# Altium schematic sheet 9-Protections 1 of 2.SchDoc("9-Protections 1 of 2")
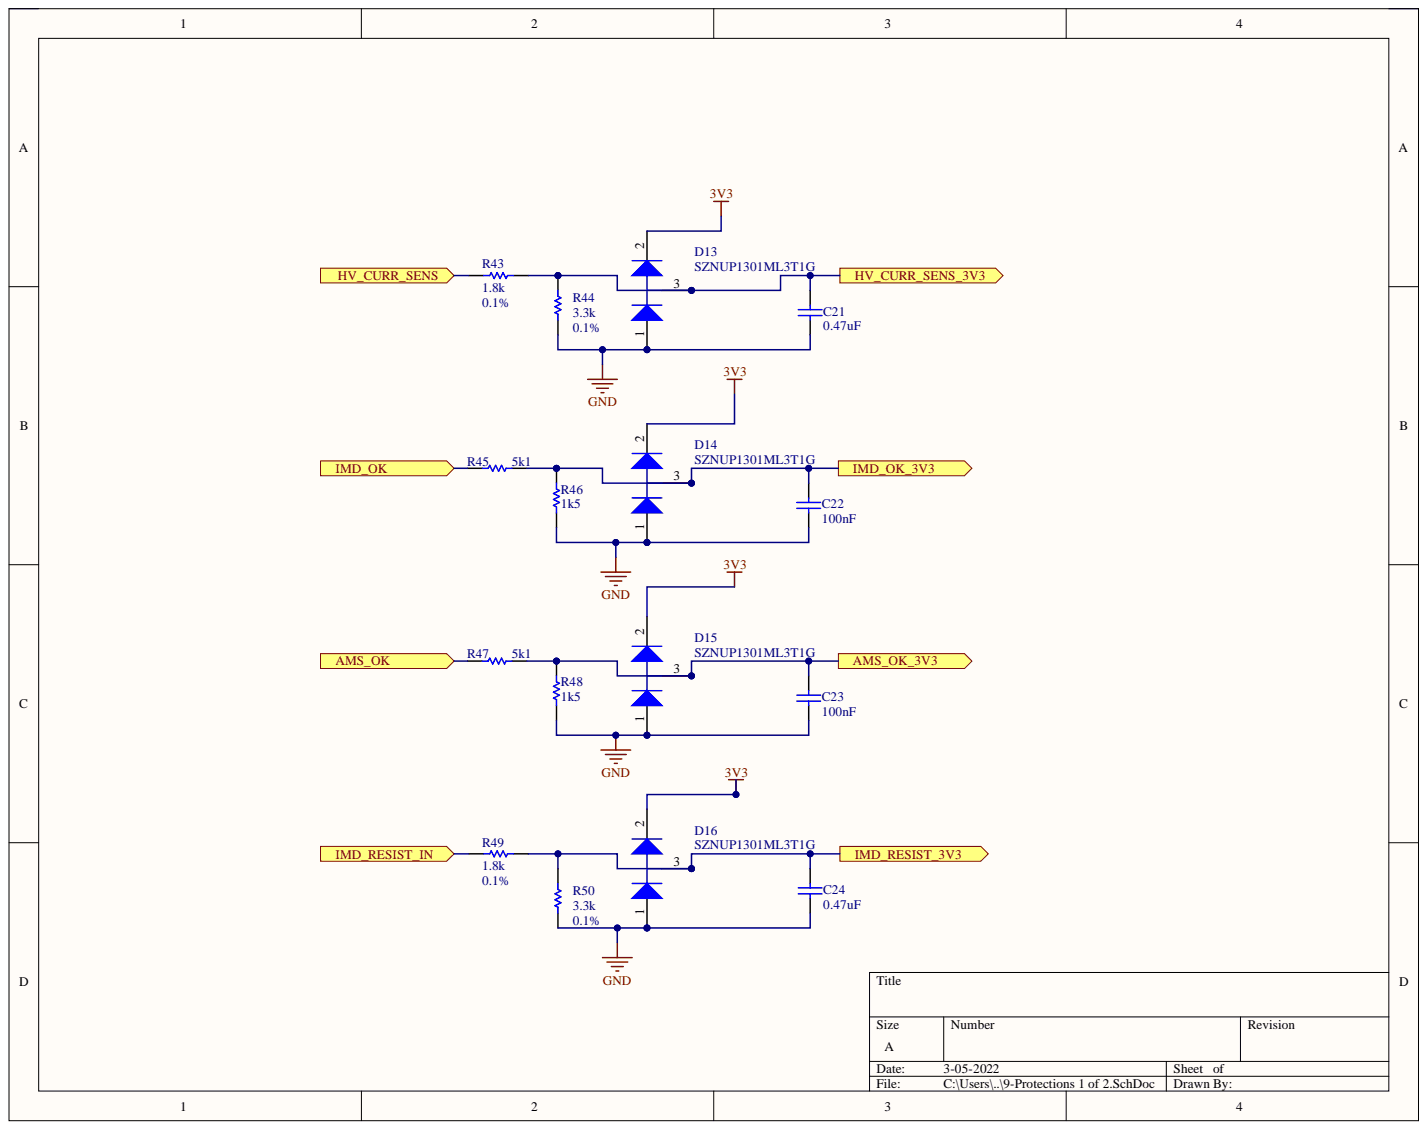
[diagram p0: 9-Protections 1 of 2.SchDoc]

## Parts
C21  value 0.47uF  [1608[0603]]  (hidden comment: Cap)
C22  value 100nF  [1608[0603]]  (hidden comment: Cap)
C23  value 100nF  [1608[0603]]  (hidden comment: Cap)
C24  value 0.47uF  [1608[0603]]  (hidden comment: Cap)
D13  SZNUP1301ML3T1G  [ONSC-SOT-23-3-318-08_V]
D14  SZNUP1301ML3T1G  [ONSC-SOT-23-3-318-08_V]
D15  SZNUP1301ML3T1G  [ONSC-SOT-23-3-318-08_V]
D16  SZNUP1301ML3T1G  [ONSC-SOT-23-3-318-08_V]
R43  value 1.8k  Resistance (Ohms): 1.8k  Tolerance: 0.1%  [1608[0603]]  (hidden comment: Res1)
R44  value 3.3k  Resistance (Ohms): 3.3k  Tolerance: 0.1%  [1608[0603]]  (hidden comment: Res1)
R45  value 5k1  [1608[0603]]  (hidden comment: Res1)
R46  value 1k5  [1608[0603]]  (hidden comment: Res1)
R47  value 5k1  [1608[0603]]  (hidden comment: Res1)
R48  value 1k5  [1608[0603]]  (hidden comment: Res1)
R49  value 1.8k  Resistance (Ohms): 1.8k  Tolerance: 0.1%  [1608[0603]]  (hidden comment: Res1)
R50  value 3.3k  Resistance (Ohms): 3.3k  Tolerance: 0.1%  [1608[0603]]  (hidden comment: Res1)

## Ports
AMS_OK, AMS_OK_3V3, HV_CURR_SENS, HV_CURR_SENS_3V3, IMD_OK, IMD_OK_3V3, IMD_RESIST_3V3, IMD_RESIST_IN

## Nets
3V3: D13.2 D14.2 D15.2 D16.2
GND: C21.1 C22.1 C23.1 C24.1 D13.1 D14.1 D15.1 D16.1 R44.1 R46.1 R48.1 R50.1
AMS_OK: R47.1 ; port AMS_OK
AMS_OK_3V3: C23.2 D15.3 R47.2 R48.2 ; port AMS_OK_3V3
HV_CURR_SENS: R43.2 ; port HV_CURR_SENS
HV_CURR_SENS_3V3: C21.2 D13.3 R43.1 R44.2 ; port HV_CURR_SENS_3V3
IMD_OK: R45.1 ; port IMD_OK
IMD_OK_3V3: C22.2 D14.3 R45.2 R46.2 ; port IMD_OK_3V3
IMD_RESIST_3V3: C24.2 D16.3 R49.1 R50.2 ; port IMD_RESIST_3V3
IMD_RESIST_IN: R49.2 ; port IMD_RESIST_IN

## Library data of the parts
C21 C24 = Cap: Capacitor; Manufacturer: Yageo; Manufacturer Part Number: CC0603KRX7R8BB474; Capacitance: 0.47µF; Temperature Coefficient: X7R; Tolerance: ±10%; Voltage - Rated: 25V; Applications: General Purpose; Category: Capacitors; Component Kind: Standard; ComponentLink1Description: http://www.yageo.com/documents/recent/UPY-GPHC_X7R_6.3V-to-50V_16.pdf; ComponentLink1URL: http://www.yageo.com/documents/recent/UPY-GPHC_X7R_6.3V-to-50V_16.pdf; Failure Rate: -; Features: -; Height - Seated (Max): -; Lead Spacing: -; Lead Style: -; Library Name: Miscellaneous Devices.IntLib; Mounting Type: Surface Mount, MLCC; Operating Temperature: -55°C ~ 125°C; Package / Case: 0603 (1608 Metric); Packaging: Cut Tape (CT); Part Status: Active; Pricing 1: 1=0.22, 10=0.158, 25=0.1156, 50=0.0898, 100=0.0745, 250=0.06168, 500=0.05226, 1000=0.04324 (CAD); Ratings: -; RoHS: RoHS Compliant; Signal Integrity: Cap; Simulation: CAP; Size / Dimension: 0.063" L x 0.031" W (1.60mm x 0.80mm); Stock 1: 11076; Supplier 1: Digi-Key; Supplier Part Number 1: 311-1805-1-ND; Thickness (Max): 0.035" (0.90mm)
C22 C23 = Cap: Capacitor; Manufacturer: Yageo; Manufacturer Part Number: CC0603KRX7R9BB104; Capacitance: 0.10µF; Temperature Coefficient: X7R; Tolerance: ±10%; Voltage - Rated: 50V; Applications: General Purpose; Category: Capacitors; Component Kind: Standard; ComponentLink1Description: http://www.yageo.com/documents/recent/UPY-GPHC_X7R_6.3V-to-50V_16.pdf; ComponentLink1URL: http://www.yageo.com/documents/recent/UPY-GPHC_X7R_6.3V-to-50V_16.pdf; Failure Rate: -; Features: -; Height - Seated (Max): -; Lead Spacing: -; Lead Style: -; Library Name: Miscellaneous Devices.IntLib; Mounting Type: Surface Mount, MLCC; Operating Temperature: -55°C ~ 125°C; Package / Case: 0603 (1608 Metric); Packaging: Cut Tape (CT); Part Status: Active; Pricing 1: 1=0.15, 10=0.043, 25=0.0312, 50=0.0232, 100=0.0189, 250=0.0158, 500=0.01346, 1000=0.01059 (CAD); Ratings: -; RoHS: RoHS Compliant; Signal Integrity: Cap; Simulation: CAP; Size / Dimension: 0.063" L x 0.031" W (1.60mm x 0.80mm); Stock 1: 4016262; Supplier 1: Digi-Key; Supplier Part Number 1: 311-1344-1-ND; Thickness (Max): 0.035" (0.90mm)
D13-D16 = CMP-1063-00171-1: Low Capacitance Diode Array for ESD Protection in a Single Data Line, 3-Pin SOT-23, Pb-Free, Tape and Reel; Manufacturer: On Semiconductor; C Max (pF): 0.9; Direction: Bidirectional; Number of Lines: 1; V(BR) Min (V): 70; Component Kind: Standard; ComponentLink1Description: Manufacturer URL; ComponentLink1URL: http://www.onsemi.com/; ComponentLink2Description: Datasheet; ComponentLink2URL: http://www.onsemi.cn/pub_link/Collateral/NUP1301ML3T1-D.PDF; ComponentLink3Description: Package Specification; ComponentLink3URL: http://www.onsemi.cn/pub_link/Collateral/318-08.PDF; DatasheetVersion: Rev. 6; Library Name: Altium Content Vault; Mounting Technology: Surface Mount; PackageDescription: 3-Pin Small Outline Transistor, Body 2.92 x 1.3 mm, Pitch 0.95 mm; PackageReference: SOT-23-3-318-08; PackageVersion: Rev. AP, 11/2009; Packing: Tape and Reel; PartNumber: SZNUP1301ML3T1G; RoHS: Pb-Free; VRWM Max (V): 70
R43 R49 = Res1: Resistor; Manufacturer: Panasonic Electronic Components; Manufacturer Part Number: ERJ-PB6B1801V; Power (Watts): 0.25W, 1/4W; Temperature Coefficient: ±50ppm/°C; Category: Resistors; Component Kind: Standard; ComponentLink1Description: https://industrial.panasonic.com/cdbs/www-data/pdf/RDM0000/AOA0000C100.pdf; ComponentLink1URL: https://industrial.panasonic.com/cdbs/www-data/pdf/RDM0000/AOA0000C100.pdf; Composition: Thick Film; Failure Rate: -; Features: Automotive AEC-Q200, Pulse Withstanding; Height - Seated (Max): 0.028" (0.70mm); Library Name: Miscellaneous Devices.IntLib; Operating Temperature: -55°C ~ 155°C; Package / Case: 0805 (2012 Metric); Packaging: Cut Tape (CT); Part Status: Active; Pricing 1: 1=0.54, 10=0.461, 25=0.3348, 50=0.243, 100=0.1801, 250=0.13812, 500=0.10886, 1000=0.07537 (CAD); RoHS: RoHS Compliant; Signal Integrity: Res; Simulation: RESISTOR; Size / Dimension: 0.079" L x 0.049" W (2.00mm x 1.25mm); Stock 1: 4965; Supplier 1: Digi-Key; Supplier Device Package: 0805; Supplier Part Number 1: P20621CT-ND
R44 R50 = Res1: Resistor; Manufacturer: Panasonic Electronic Components; Manufacturer Part Number: ERJ-PB6B3301V; Power (Watts): 0.25W, 1/4W; Temperature Coefficient: ±50ppm/°C; Category: Resistors; Component Kind: Standard; ComponentLink1Description: https://industrial.panasonic.com/cdbs/www-data/pdf/RDM0000/AOA0000C100.pdf; ComponentLink1URL: https://industrial.panasonic.com/cdbs/www-data/pdf/RDM0000/AOA0000C100.pdf; Composition: Thick Film; Failure Rate: -; Features: Automotive AEC-Q200, Pulse Withstanding; Height - Seated (Max): 0.028" (0.70mm); Library Name: Miscellaneous Devices.IntLib; Operating Temperature: -55°C ~ 155°C; Package / Case: 0805 (2012 Metric); Packaging: Cut Tape (CT); Part Status: Active; Pricing 1: 1=0.54, 10=0.461, 25=0.3348, 50=0.243, 100=0.1801, 250=0.13812, 500=0.10886, 1000=0.07537 (CAD); RoHS: RoHS Compliant; Signal Integrity: Res; Simulation: RESISTOR; Size / Dimension: 0.079" L x 0.049" W (2.00mm x 1.25mm); Stock 1: 4675; Supplier 1: Digi-Key; Supplier Device Package: 0805; Supplier Part Number 1: P20651CT-ND
R45-R48 = Res1: Resistor; Manufacturer: Yageo; Manufacturer Part Number: RC0603JR-075K1L; Power (Watts): 0.1W, 1/10W; Resistance (Ohms): 5.1k; Temperature Coefficient: ±100ppm/°C; Tolerance: ±5%; Category: Resistors; Component Kind: Standard; ComponentLink1Description: http://www.yageo.com/NewPortal/yageodocoutput?fileName=/pdf/R-Chip/PYu-RC_Group_51_RoHS_L_4.pdf; ComponentLink1URL: http://www.yageo.com/NewPortal/yageodocoutput?fileName=/pdf/R-Chip/PYu-RC_Group_51_RoHS_L_4.pdf; Composition: Thick Film; Failure Rate: -; Features: Moisture Resistant; Height - Seated (Max): 0.022" (0.55mm); Library Name: Miscellaneous Devices.IntLib; Number of Terminations: 2; Operating Temperature: -55°C ~ 155°C; Package / Case: 0603 (1608 Metric); Packaging: Cut Tape (CT); Part Status: Active; RoHS: RoHS Compliant; Signal Integrity: Res; Simulation: RESISTOR; Size / Dimension: 0.063" L x 0.031" W (1.60mm x 0.80mm); Supplier 1: Digi-Key; Supplier Device Package: 0603
  R45 R47: Pricing 1: 1=0.16, 10=0.016, 25=0.0112, 50=0.0084, 100=0.0062, 250=0.0048, 500=0.00382, 1000=0.00281 (CAD); Stock 1: 782184; Supplier Part Number 1: 311-5.1KGRCT-ND
  R46 R48: ComponentLink2Description: http://www.vishay.com/docs/20065/rcse3.pdf; ComponentLink2URL: http://www.vishay.com/docs/20065/rcse3.pdf; Pricing 1: 1=0.21, 10=0.192, 25=0.1332, 50=0.1038, 100=0.0741, 250=0.05776, 500=0.04586, 1000=0.03257 (CAD); Stock 1: 14165; Supplier Part Number 1: 541-2789-1-ND
